# Altium schematic sheet Power and Connectors.SchDoc
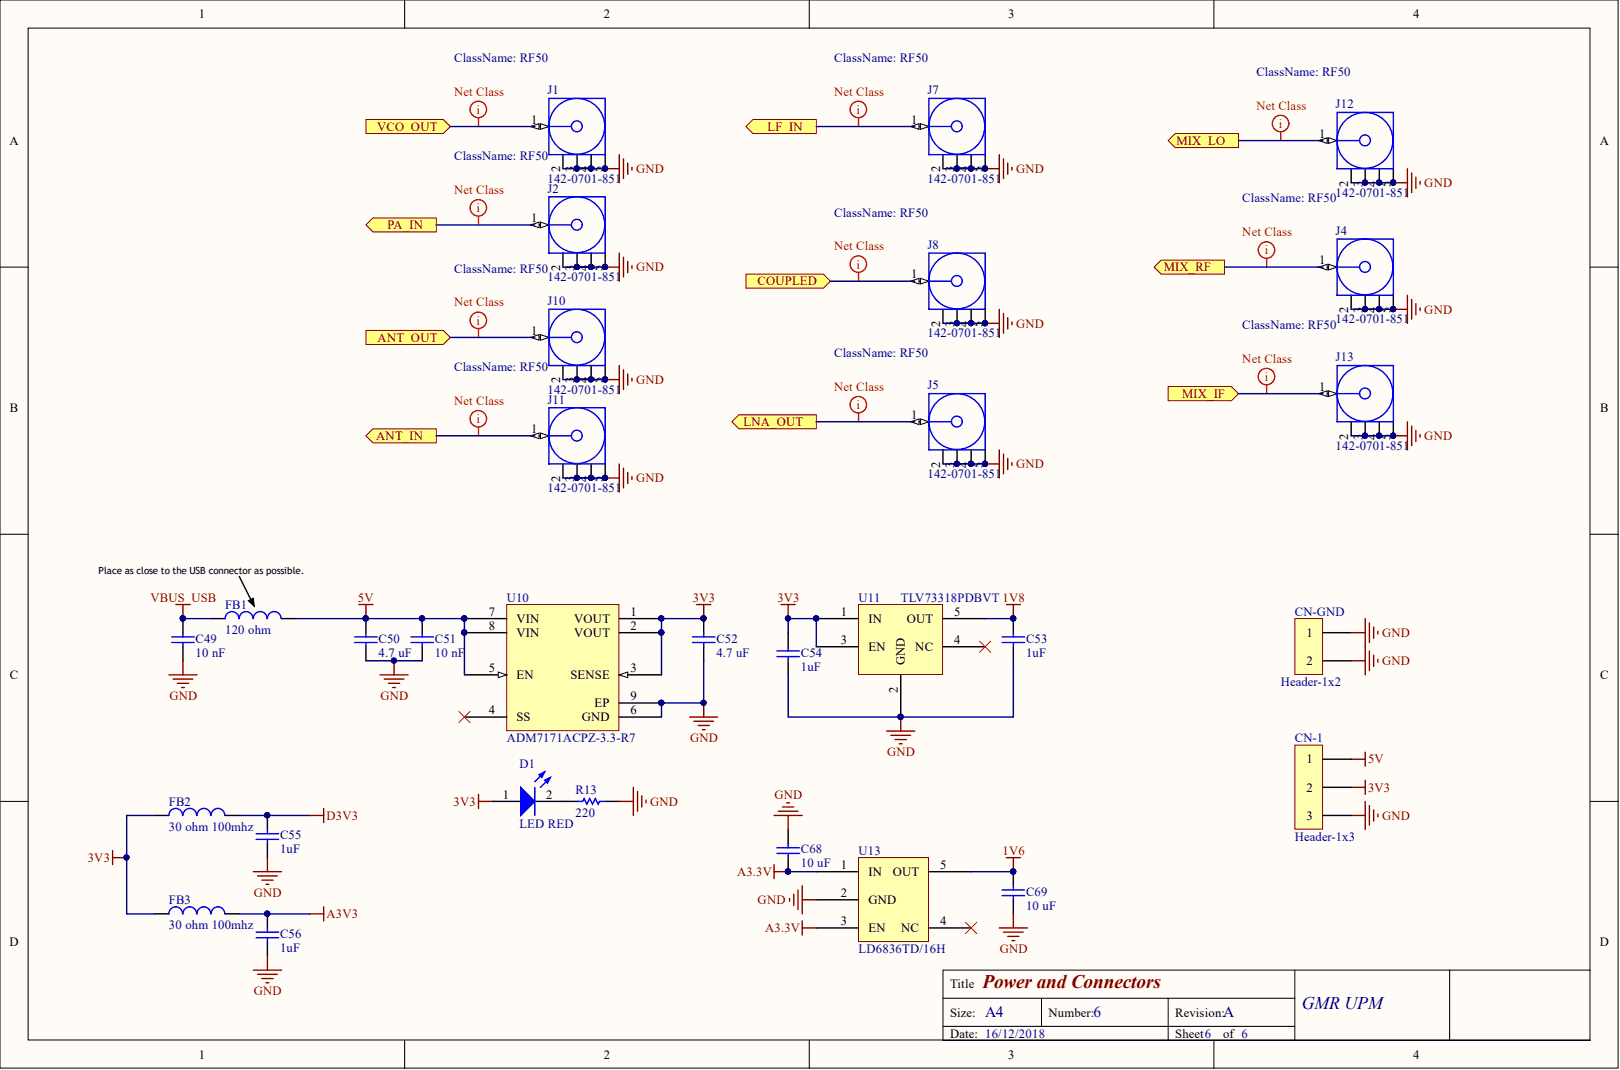
[diagram p0: Power and Connectors.SchDoc]

## Parts
C49  10 nF  [Chip2PinSM127P600-8N_CAP0402]
C50  4.7 uF  [CAPC1608X10L]
C51  10 nF  [Chip2PinSM127P600-8N_CAP0402]
C52  4.7 uF  [CAPC1608X10L]
C53  1uF  [0603 Cap]
C54  1uF  [0603 Cap]
C55  1uF  [0603 Cap]
C56  1uF  [0603 Cap]
C68  10 uF  [CAPC1608X10L]
C69  10 uF  [CAPC1608X10L]
CN-1  Header-1x3  [Header-1x3-Jumper]
CN-GND  Header-1x2  [HEADER-1x2-Jumper]
D1  LED RED  [0603 LED]
FB1  120 ohm  [INDC1608X08L]
FB2  30 ohm 100mhz  [0805 Ind]
FB3  30 ohm 100mhz  [0805 Ind]
J1  142-0701-851  [142-0701-851]
J2  142-0701-851  [142-0701-851]
J4  142-0701-851  [142-0701-851]
J5  142-0701-851  [142-0701-851]
J7  142-0701-851  [142-0701-851]
J8  142-0701-851  [142-0701-851]
J10  142-0701-851  [142-0701-851]
J11  142-0701-851  [142-0701-851]
J12  142-0701-851  [142-0701-851]
J13  142-0701-851  [142-0701-851]
R13  220  [0603 Res]
U10  ADM7171ACPZ-3.3-R7  [SON50P300X80_HS-9N LFCSP_WD Analog]
U11  TLV73318PDBVT  [SOT95P280X145-5N]
U13  LD6836TD/16H  [SOT-753]

## Ports
ANT_IN, ANT_OUT, COUPLED, LF_IN, LNA_OUT, MIX_IF, MIX_LO, MIX_RF, PA_IN, VCO_OUT

## Nets
1V6: C69.2 U13.5(OUT)
1V8: C53.2 U11.5(OUT)
3V3: C52.2 C54.2 CN-1.2 D1.1 FB2.1 FB3.1 U10.1(VOUT) U10.2(VOUT) U10.3(SENSE) U11.1(IN) U11.3(EN)
5V: C50.2 C51.2 CN-1.1 FB1.2 U10.5(EN) U10.7(VIN) U10.8(VIN)
A3.3V: C68.1 U13.1(IN) U13.3(EN)
A3V3: C56.2 FB3.2
D3V3: C55.2 FB2.2
GND: C49.1 C50.1 C51.1 C52.1 C53.1 C54.1 C55.1 C56.1 C68.2 C69.1 CN-1.3 CN-GND.1 CN-GND.2 J1.2 J1.3 J1.4 J1.5 J2.2 J2.3 J2.4 J2.5 J4.2 J4.3 J4.4 J4.5 J5.2 J5.3 J5.4 J5.5 J7.2 J7.3 J7.4 J7.5 J8.2 J8.3 J8.4 J8.5 J10.2 J10.3 J10.4 J10.5 J11.2 J11.3 J11.4 J11.5 J12.2 J12.3 J12.4 J12.5 J13.2 J13.3 J13.4 J13.5 R13.2 U10.6(GND) U10.9(EP) U11.2(GND) U13.2(GND)
VBUS_USB: C49.2 FB1.1
ANT_IN: J11.1 ; port ANT_IN
ANT_OUT: J10.1 ; port ANT_OUT
COUPLED: J8.1 ; port COUPLED
LF_IN: J7.1 ; port LF_IN
LNA_OUT: J5.1 ; port LNA_OUT
MIX_IF: J13.1 ; port MIX_IF
MIX_LO: J12.1 ; port MIX_LO
MIX_RF: J4.1 ; port MIX_RF
PA_IN: J2.1 ; port PA_IN
VCO_OUT: J1.1 ; port VCO_OUT
NetD1_2: D1.2 R13.1

Not connected: U10 4(SS); U11 4(NC); U13 4(NC)

## Library data of the parts
C49 C51 = CL05B103KA5NNNC: CAP CER 10000PF 25V X7R 0402; Manufacturer: Samsung Electro-Mechanics America, Inc.; Tolerance: ±10%; Applications: General Purpose; Capacitance: 10000pF; Category: Capacitors; Component Kind: Standard; ComponentLink1Description: http://www.samsungsem.com/kr/support/product-search/mlcc/__icsFiles/afieldfile/2016/08/18/S_CL05B103KA5NNNC.pdf; ComponentLink1URL: http://www.samsungsem.com/kr/support/product-search/mlcc/__icsFiles/afieldfile/2016/08/18/S_CL05B103KA5NNNC.pdf; Features: -; Height - Seated (Max): -; Lead Spacing: -; Lead Style: -; Library Name: Components.SchLib; Manufacturer Part Number: CL05B103KA5NNNC; Mounting Type: Surface Mount, MLCC; Operating Temperature: -55°C ~ 125°C; Package / Case: 0402 (1005 Metric); Packaging: Cut Tape (CT); Part Status: Active; Pricing 1: 1=0.09, 10=0.009, 25=0.006, 50=0.0044, 100=0.0037, 250=0.00308, 500=0.00266, 1000=0.00208, 5000=0.00174 (EUR); Ratings: -; RoHS: RoHS Compliant; Size / Dimension: 0.039" L x 0.020" W (1.00mm x 0.50mm); Stock 1: 615673; Supplier 1: Digi-Key; Supplier Part Number 1: 1276-1057-1-ND; Temperature Coefficient: X7R; Thickness (Max): 0.022" (0.55mm); Voltage - Rated: 25V
C50 C52 = GRM188R61C475KAAJD: Multilayer Ceramic Capacitors MLCC - SMD/SMT 0603 4.7uF 16volts X5R + - 10%; Brand: Murata Electronics; Product Status: New Product; Type: General Purpose MLCC; Voltage - Rated: 16V; Voltage Rating DC: 16 V; Applications: General Purpose; Capacitance: 4.7 uF; Case Code - in: 0603; Case Code - mm: 1608; Category: Multilayer Ceramic Capacitors MLCC - SMD/SMT; Component Kind: Standard; ComponentLink1Description: http://www.mouser.com/ds/2/281/c02e-2905.pdf; ComponentLink1URL: http://www.mouser.com/ds/2/281/c02e-2905.pdf; ComponentLink2Description: http://www.murata.com/~/media/webrenewal/support/library/catalog/products/capacitor/mlcc/c02e.ashx; ComponentLink2URL: http://www.murata.com/~/media/webrenewal/support/library/catalog/products/capacitor/mlcc/c02e.ashx; Dielectric: X5R; Features: -; Height: 0.8 mm; Height - Seated (Max): -; Lead Spacing: -; Lead Style: -; Length: 1.6 mm; Library Name: Components.SchLib; Manufacturer: Murata Electronics; Manufacturer Part Number: GRM188R61C475KAAJD; Maximum Operating Temperature: + 85 C; Minimum Operating Temperature: - 55 C; Minimum Order Quantity: 1; Mounting Type: Surface Mount, MLCC; Operating Temperature: -55°C ~ 85°C; Package / Case: 0603 (1608 Metric); Package/Case: 0603 (1608 metric); Packaging: Reel; Pricing 1: 1=0.262, 10=0.110, 100=0.066, 1000=0.056, 4000=0.042, 8000=0.040, 24000=0.037, 48000=0.035, 100000=0.030 (EUR); Pricing 2: 1=0.27, 10=0.224, 25=0.154, 50=0.1262, 100=0.112, 250=0.08404, 500=0.07424, 1000=0.06582 (EUR); Product: General Type MLCCs; Ratings: -; Reeling: True; RoHS: RoHS Compliant; RoHS - Mouser: Y; Series: GRM; Size / Dimension: 0.063" L x 0.031" W (1.60mm x 0.80mm); Stock 1: 10870; Stock 2: 0; Supplier 1: Mouser; Supplier 2: Digi-Key; Supplier Part Number 1: 81-GRM188R61C475KAJD; Supplier Part Number 2: 490-10481-1-ND; Temperature Coefficient: X5R; Termination Style: SMD/SMT; Thickness (Max): 0.037" (0.95mm); Tolerance: 10 %; Width: 0.8 mm
C53-C56 = CL10B105MO8NNWC: CAP CER 1UF 16V X7R 0603; Manufacturer: Samsung Electro-Mechanics America, Inc.; Capacitance: 1µF; Tolerance: ±20%; Applications: General Purpose; Category: Capacitors; Component Kind: Standard; ComponentLink1Description: http://www.samsungsem.com/kr/support/library/product-catalog/__icsFiles/afieldfile/2016/09/27/MLCC.pdf; ComponentLink1URL: http://www.samsungsem.com/kr/support/library/product-catalog/__icsFiles/afieldfile/2016/09/27/MLCC.pdf; Features: -; Height - Seated (Max): -; Lead Spacing: -; Lead Style: -; Manufacturer Part Number: CL10B105MO8NNWC; Mounting Type: Surface Mount, MLCC; Operating Temperature: -55°C ~ 125°C; Package / Case: 0603 (1608 Metric); Packaging: Digi-Reel®; Part Status: Active; Pricing 1: 1=0.09, 10=0.02, 25=0.0144, 50=0.0108, 100=0.0089, 250=0.00744, 500=0.00636, 1000=0.00499 (EUR); Ratings: -; RoHS: RoHS Compliant; Size / Dimension: 0.063" L x 0.031" W (1.60mm x 0.80mm); Stock 1: 77746; Supplier 1: Digi-Key; Supplier Part Number 1: 1276-6524-6-ND; Temperature Coefficient: X7R; Thickness (Max): 0.035" (0.90mm); Voltage - Rated: 16V
  C53 C54: Library Name: Componentes_base.SchLib
  C55 C56: Library Name: componentes.SchLib
C68 C69 = GRM188R61C106MA73D: CAP CER 10UF 16V 20% X5R 0603; Manufacturer: Murata Electronics North America; Capacitance: 10µF; Applications: General Purpose; Category: Capacitors; Component Kind: Standard; ComponentLink1Description: http://www.murata.com/~/media/webrenewal/support/library/catalog/products/capacitor/mlcc/c02e.ashx; ComponentLink1URL: http://www.murata.com/~/media/webrenewal/support/library/catalog/products/capacitor/mlcc/c02e.ashx; Features: -; Height - Seated (Max): -; Lead Spacing: -; Lead Style: -; Library Name: Componentes_base.SchLib; Manufacturer Part Number: GRM188R61C106MA73D; Mounting Type: Surface Mount, MLCC; Operating Temperature: -55°C ~ 85°C; Package / Case: 0603 (1608 Metric); Packaging: Cut Tape (CT); Pricing 1: 1=0.26, 10=0.247, 25=0.212, 50=0.1802, 100=0.1731, 250=0.15544, 500=0.14838, 1000=0.12365 (EUR); Ratings: -; RoHS: RoHS Compliant; Size / Dimension: 0.063" L x 0.031" W (1.60mm x 0.80mm); Stock 1: 6341; Supplier 1: Digi-Key; Supplier Part Number 1: 490-7201-1-ND; Temperature Coefficient: X5R; Thickness (Max): 0.031" (0.80mm); Tolerance: ±20%; Voltage - Rated: 16V
D1 = LTST-C191KRKT: LED RED CLEAR 0603 SMD; Manufacturer: Lite-On Inc.; Current - Test: 20mA; Lens Color: Colorless; Lens Style/Size: Rectangle with Flat Top, 1.10mm x 0.80mm; Millicandela Rating: 54mcd; Viewing Angle: 130°; Voltage - Forward (Vf) (Typ): 2V; Wavelength - Dominant: 631nm; Wavelength - Peak: 639nm; Category: Optoelectronics; Color: Red; Component Kind: Standard; ComponentLink1Description: http://optoelectronics.liteon.com/upload/download/DS22-2000-223/S_110_LTST-C191KRKT.pdf; ComponentLink1URL: http://optoelectronics.liteon.com/upload/download/DS22-2000-223/S_110_LTST-C191KRKT.pdf; Features: -; Height (Max): 0.65mm; Lens Transparency: Clear; Library Name: Componentes_base.SchLib; Manufacturer Part Number: LTST-C191KRKT; Mounting Type: Surface Mount; Package / Case: 0603 (1608 Metric); Packaging: Digi-Reel®; Part Status: Active; Pricing 1: 1=0.27, 10=0.197, 25=0.1456, 100=0.1039, 250=0.07068, 500=0.06234, 1000=0.04884, 2500=0.0478 (EUR); RoHS: RoHS Compliant; Size / Dimension: 1.60mm L x 0.80mm W; Stock 1: 517799; Supplier 1: Digi-Key; Supplier Part Number 1: 160-1447-6-ND
FB1 = BLM18PG121SN1D: FERRITE BEAD 120 OHM 0603 1LN; Manufacturer: Murata Electronics North America; Current Rating (Max): 2A; DC Resistance (DCR) (Max): 50 mOhm; Filter Type: Power Line; Impedance @ Frequency: 120 Ohm @ 100MHz; Number of Lines: 1; Category: Filters; Component Kind: Standard; ComponentLink1Description: http://www.murata.com/en-us/products/productdata/8796738650142/ENFA0003.pdf; ComponentLink1URL: http://www.murata.com/en-us/products/productdata/8796738650142/ENFA0003.pdf; Height (Max): 0.037" (0.95mm); Library Name: Componentes_base.SchLib; Manufacturer Part Number: BLM18PG121SN1D; Mounting Type: Surface Mount; Operating Temperature: -55°C ~ 125°C; Package / Case: 0603 (1608 Metric); Packaging: Cut Tape (CT); Part Status: Active; Pricing 1: 1=0.09, 10=0.067, 25=0.06, 50=0.0438, 100=0.038, 250=0.02996, 500=0.0253, 1000=0.02132 (EUR); Ratings: -; RoHS: RoHS Compliant; Size / Dimension: 0.063" L x 0.031" W (1.60mm x 0.80mm); Stock 1: 1305577; Supplier 1: Digi-Key; Supplier Part Number 1: 490-1037-1-ND
FB2 FB3 = MH2029-300Y: FERRITE BEAD 30 OHM 0805 1LN; Manufacturer: Bourns Inc.; Current Rating (Max): 3A; DC Resistance (DCR) (Max): 25 mOhm; Filter Type: Power, Signal Line; Impedance @ Frequency: 30 Ohm @ 100MHz; Number of Lines: 1; Category: Filters; Component Kind: Standard; ComponentLink1Description: http://www.bourns.com/docs/Product-Datasheets/mh.pdf; ComponentLink1URL: http://www.bourns.com/docs/Product-Datasheets/mh.pdf; Height (Max): 0.043" (1.10mm); Manufacturer Part Number: MH2029-300Y; Mounting Type: Surface Mount; Operating Temperature: -55°C ~ 125°C; Package / Case: 0805 (2012 Metric); Packaging: Cut Tape (CT); Part Status: Active; Pricing 1: 1=0.09, 10=0.058, 25=0.0532, 50=0.0476, 100=0.0351, 250=0.02548, 500=0.02336, 1000=0.02017 (EUR); Ratings: -; RoHS: RoHS Compliant; Size / Dimension: 0.079" L x 0.047" W (2.00mm x 1.20mm); Stock 1: 66775; Supplier 1: Digi-Key; Supplier Part Number 1: MH2029-300YCT-ND
  FB2: Library Name: Componentes_base.SchLib
  FB3: Library Name: componentes.SchLib
J1 J2 J4 J5 J7 J8 J10-J13 = 142-0701-851: RF Connectors / Coaxial Connectors PC END MT JCK GLD .062 BOARDS TAB; Body Finish: Gold; Body Material: Brass; Body Style: Straight; Brand: Johnson / Cinch Connectivity Solutions; Center Contact Material: Beryllium Copper; Connector Style: SMA; Connector Type: Jack, Female Socket; Contact Termination: Solder; Dielectric Material: Polytetrafluoroethylene (PTFE); Frequency - Max: 18GHz; Gender: Jack (Female); Impedance: 50 Ohms; Maximum Frequency: 18 GHz; Voltage Rating: 335V; Cable Group: -; Category: RF Connectors / Coaxial Connectors; Center Contact Plating: Gold; Component Kind: Standard; ComponentLink1Description: http://emersonconnectivity.com/OA_HTML/ibeCCtpItmDspRte.jsp?item=4253264; ComponentLink1URL: http://emersonconnectivity.com/OA_HTML/ibeCCtpItmDspRte.jsp?item=4253264; ComponentLink2Description: http://emersonconnectivity.com/OA_MEDIA/drawings/dr-1420701851.pdf; ComponentLink2URL: http://emersonconnectivity.com/OA_MEDIA/drawings/dr-1420701851.pdf; Contact Plating: Gold; Fastening Type: Threaded; Features: -; Housing Color: Gold; Ingress Protection: -; Library Name: Componentes_base.SchLib; Manufacturer: Johnson / Cinch Connectivity Solutions; Manufacturer Part Number: 142-0701-851; Minimum Order Quantity: 1; Mounting Type: Board Edge, End Launch; Operating Temperature: -65°C ~ 165°C; Operating Temperature Range: - 65 C to + 165 C; Orientation: Straight; Packaging: Bulk; Pricing 1: 1=4.65, 10=4.13, 25=3.56, 100=3.09, 500=2.49, 1000=2.34 (EUR); Pricing 2: 1=5.23, 10=4.656, 50=3.8012, 100=3.421, 250=3.13592, 500=2.66078, 750=2.52775, 1000=2.3757, 2500=2.28068 (EUR); Product: Connectors; Product Category: SMA Connectors; Reeling: False; RF Series: SMA; RoHS: RoHS Compliant; RoHS - Mouser: Y; Series: SMA; Shield Termination: Solder; Standard Pack Qty: 5; Stock 1: 21985; Stock 2: 64832; Supplier 1: Mouser; Supplier 2: Digi-Key; Supplier Part Number 1: 530-142-0701-851; Supplier Part Number 2: J658-ND; Termination Style: Solder
R13 = RC0603FR-07220RL: RES SMD 220 OHM 1% 1/10W 0603; Manufacturer: Yageo; Power (Watts): 0.1W, 1/10W; Temperature Coefficient: ±100ppm/°C; Category: Resistors; Component Kind: Standard; ComponentLink1Description: http://www.yageo.com/documents/recent/PYu-RC_Group_51_RoHS_L_7.pdf; ComponentLink1URL: http://www.yageo.com/documents/recent/PYu-RC_Group_51_RoHS_L_7.pdf; Composition: Thick Film; Failure Rate: -; Features: Moisture Resistant; Height - Seated (Max): 0.022" (0.55mm); Library Name: Componentes_base.SchLib; Manufacturer Part Number: RC0603FR-07220RL; Operating Temperature: -55°C ~ 155°C; Package / Case: 0603 (1608 Metric); Packaging: Cut Tape (CT); Part Status: Active; Pricing 1: 1=0.09, 10=0.012, 25=0.0088, 50=0.0066, 100=0.0049, 250=0.00372, 500=0.00296, 1000=0.00218 (EUR); Resistance (Ohms): 220; RoHS: RoHS Compliant; Size / Dimension: 0.063" L x 0.031" W (1.60mm x 0.80mm); Stock 1: 644291; Supplier 1: Digi-Key; Supplier Device Package: 0603; Supplier Part Number 1: 311-220HRCT-ND; Tolerance: ±1%
U10 = ADM7171ACPZ-3.3-R7: IC REG LDO 3.3V 1A 8-LFCSP; Manufacturer: Analog Devices Inc.; Current - Limit (Min): 1.3A; Number of Regulators: 1; Regulator Topology: Positive Fixed; Voltage - Dropout (Typical): 0.084V @ 1A; Voltage - Input: Up to 6.5V; Category: Integrated Circuits (ICs); Component Kind: Standard; ComponentLink1Description: http://www.analog.com/media/en/technical-documentation/data-sheets/ADM7171.pdf; ComponentLink1URL: http://www.analog.com/media/en/technical-documentation/data-sheets/ADM7171.pdf; Current - Output: 1A; Library Name: Components.SchLib; Manufacturer Part Number: ADM7171ACPZ-3.3-R7; Mounting Type: Surface Mount; Operating Temperature: -40°C ~ 125°C; Package / Case: 8-WFDFN Exposed Pad, CSP; Packaging: Cut Tape (CT); Part Status: Active; Pricing 1: 1=2.96, 10=2.655, 100=2.1757, 500=1.85208 (EUR); RoHS: RoHS Compliant; Stock 1: 1479; Supplier 1: Digi-Key; Supplier Device Package: 8-LFCSP-WD (3x3); Supplier Part Number 1: ADM7171ACPZ-3.3-R7CT-ND; Voltage - Output: 3.3V
U11 = TLV73318PDBVT: IC REG LINEAR 1.8V 300MA SOT23-5; Manufacturer: Texas Instruments; Control Features: Enable; Current - Supply: 60µA; Output Configuration: Positive; Output Type: Fixed; Protection Features: Over Current, Over Temperature, Short Circuit, Under Voltage Lockout (UVLO); PSRR: 68dB ~ 28dB (100Hz ~ 100kHz); Voltage - Input (Max): 5.5V; Voltage Dropout (Max): 0.3V @ 300mA; Category: Integrated Circuits (ICs); Component Kind: Standard; ComponentLink1Description: http://www.ti.com/lit/ds/symlink/tlv733p.pdf; ComponentLink1URL: http://www.ti.com/lit/ds/symlink/tlv733p.pdf; Current - Output: 300mA; Library Name: Componentes_base.SchLib; Manufacturer Part Number: TLV73318PDBVT; Mounting Type: Surface Mount; Operating Temperature: -40°C ~ 125°C; Package / Case: SC-74A, SOT-753; Packaging: Cut Tape (CT); Part Status: Active; Pricing 1: 1=0.34, 10=0.291, 100=0.2177 (EUR); RoHS: RoHS Compliant; Stock 1: 3652; Supplier 1: Digi-Key; Supplier Device Package: SOT-23-5; Supplier Part Number 1: 296-38490-1-ND; Voltage - Output (Max): -; Voltage - Output (Min/Fixed): 1.8V
U13 = LD6836TD/16H: NEXPERIA - LD6836TD/16H - LDO, 5,5V, 0.09VDO, 0,3A, 1,6V, 5SOT753; Corriente de Salida: 300; Encapsulado: Individual; Interface: Parallel; Memory Format: DRAM; Memory Size: 64Mb (4M x 16); Memory Type: Volatile; Nivel de Sensibilidad a la Humedad (MSL): MSL 1 - Ilimitado; Núm. de Contactos Macho: 5; Rango de Producto: 1.6V 300; Speed: 143MHz; Sustancia Extremadamente Preocupante (SVHC): No SVHC (17-Dec-2015); Temperatura de Trabajo Máx.: 85; Temperatura de Trabajo Mín.: -40; Tensión de Caída Vdo: 100; Tensión de Entrada Máx.: 5.5; Tensión de Entrada Mín.: 2.3; Tensión Nominal de Salida: 1.6; Tipo de Salida: Fija; Voltage - Supply: 3 V ~ 3.6 V; Category: Integrated Circuits (ICs); Component Kind: Standard; ComponentLink1Description: http://www.farnell.com/datasheets/1760785.pdf; ComponentLink1URL: http://www.farnell.com/datasheets/1760785.pdf; ComponentLink2Description: http://www.farnell.com/datasheets/1697991.pdf; ComponentLink2URL: http://www.farnell.com/datasheets/1697991.pdf; ComponentLink3Description: http://www.farnell.com/cad/1721740.zip; ComponentLink3URL: http://www.farnell.com/cad/1721740.zip; ComponentLink4Description: http://www.issi.com/WW/pdf/42-45S16400J.pdf; ComponentLink4URL: http://www.issi.com/WW/pdf/42-45S16400J.pdf; Diseño del Regulador LDO: SOT-753; Estándar de Certificación para Automoción: -; Library Name: Componentes_base.SchLib; Manufacturer: NEXPERIA; Manufacturer Part Number: LD6836TD/16H; Operating Temperature: 0°C ~ 70°C (TA); Package / Case: 54-TSOP (0.400", 10.16mm Width); Packaging: Tray; Part Status: Active; Pricing 1: 1=0,338, 40=0,273, 100=0,223, 300=0,183, 1000=0,16, 3000=0,133, 10000=0,125, 25000=0,115 (EUR); RoHS: YES; Stock 1: 57; Supplier 1: Farnell; Supplier Device Package: 54-TSOP II; Supplier Part Number 1: 2308313RL; Tensión Máxima de Salida Ajustable: -; Tensión Mínima de Salida Ajustable: -; Tensión Nominal de Salida Fija: 1.6; Voltaje de Entrada, Primario: 5.5
CN-1 = Header-1x3: Component Kind: Standard; Library Name: B105lib.IntLib
CN-GND = Header-1x2: Component Kind: Standard; Library Name: B105lib.IntLib
